# Altium schematic sheet LNA.SchDoc
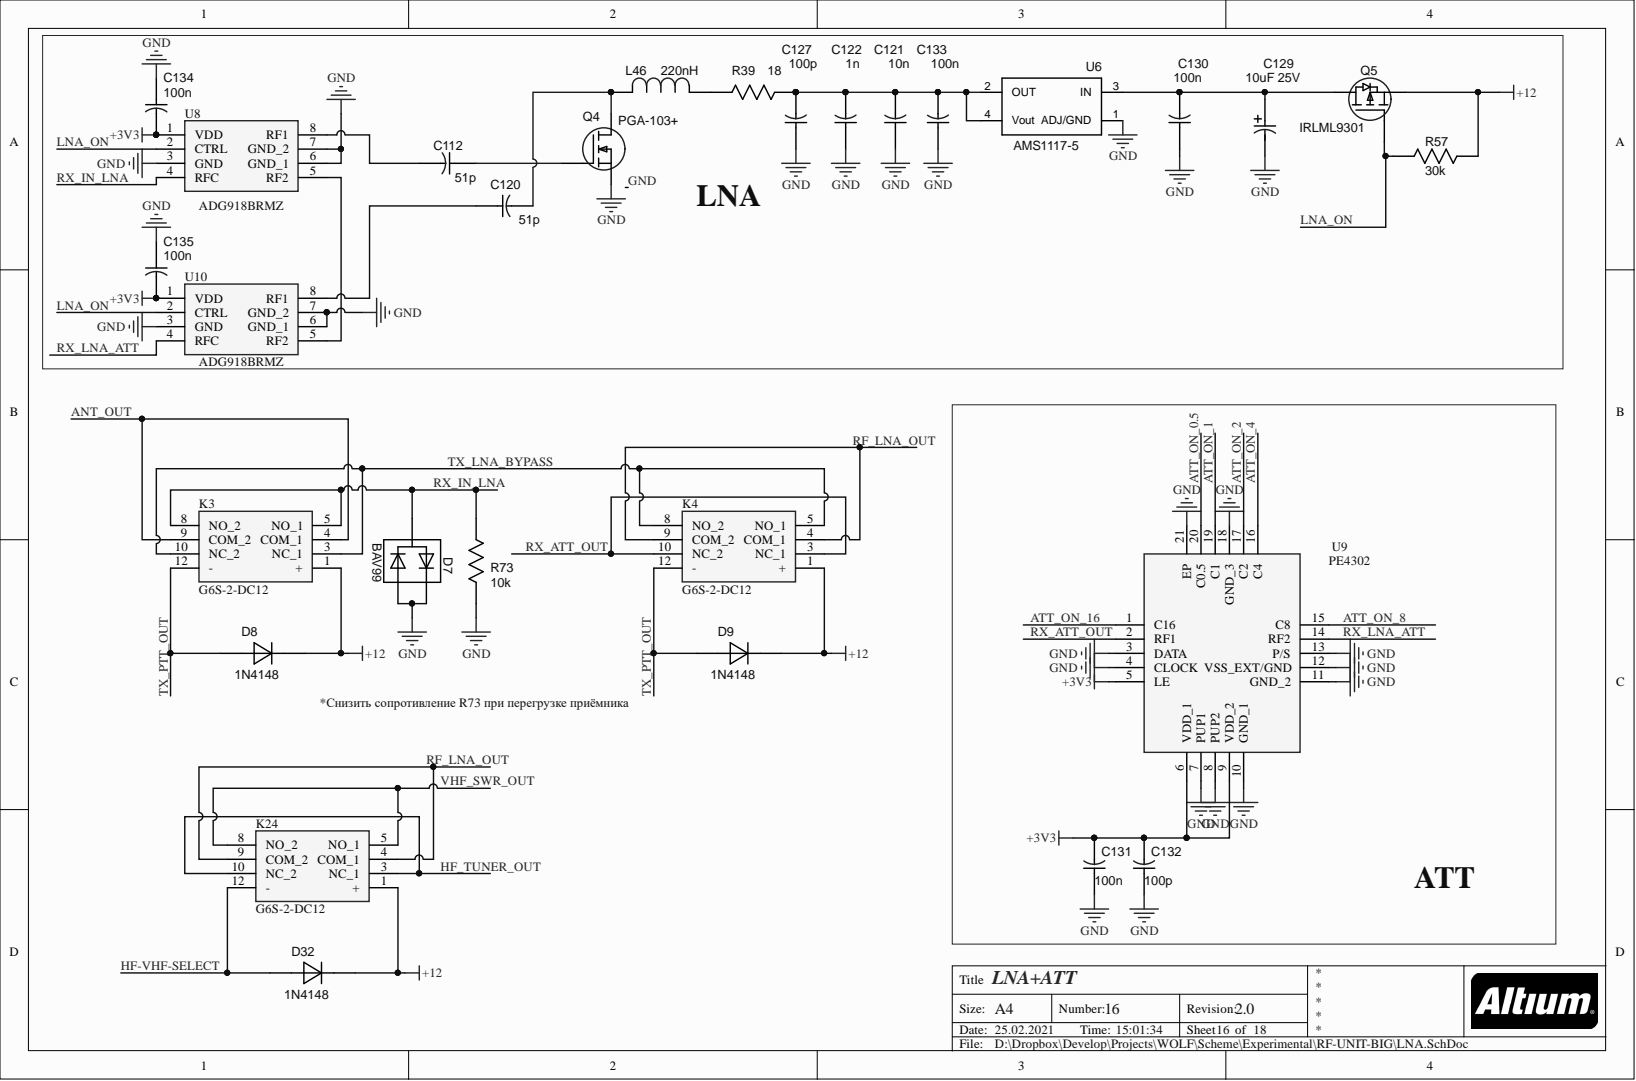
[diagram p0: LNA.SchDoc]

## Parts
C112  51p  [CAP_0805]
C120  51p  [CAP_0805]
C121  10n  [6-0805_M]
C122  1n  [6-0805_M]
C127  100p  [6-0805_M]
C129  10uF 25V  [CAPAE_5.3x5.3h6.1]
C130  100n  [CAP_0805]
C131  100n  [6-0805_M]
C132  100p  [6-0805_M]
C133  100n  [CAP_0805]
C134  100n  [CAP_0805]
C135  100n  [CAP_0805]
D7  BAV99  [SOT23]
D8  1N4148  [SS14]
D9  1N4148  [SS14]
D32  1N4148  [SS14]
K3  G6S-2-DC12  [G6S2DC12]
K4  G6S-2-DC12  [G6S2DC12]
K24  G6S-2-DC12  [G6S2DC12]
L46  220nH  [IND_1206]
Q4  PGA-103+  [SOT89]
Q5  IRLML9301  [SOT23]
R39  18  [RES_1206]
R57  30k  [RES_0805]
R73  10k  [RES_1206]
U6  AMS1117-5  [AMS1117-5]
U8  ADG918BRMZ  [SOP65P490X110-8N]
U9  PE4302  [QFN50P400X400X90-21N]
U10  ADG918BRMZ  [SOP65P490X110-8N]

## Nets
+3V3: C131.2 C132.2 C134.2 C135.2 U8.1(VDD) U9.5(LE) U9.6(VDD_1) U9.9(VDD_2) U10.1(VDD)
+12: D8.1 D9.1 D32.1 K3.1(+) K4.1(+) K24.1(+) Q5.2 R57.2
ANT_OUT: K3.4(COM_1) K3.9(COM_2)
ATT_ON_0.5: U9.20(C0.5)
ATT_ON_1: U9.19(C1)
ATT_ON_2: U9.17(C2)
ATT_ON_4: U9.16(C4)
ATT_ON_8: U9.15(C8)
ATT_ON_16: U9.1(C16)
GND: C121.1 C122.1 C127.1 C129.2 C130.1 C131.1 C132.1 C133.1 C134.1 C135.1 D7.1 D7.2 Q4.0 Q4.2 R73.1 U6.1(ADJ/GND) U8.3(GND) U8.6(GND_1) U8.7(GND_2) U9.3(DATA) U9.4(CLOCK) U9.7(PUP1) U9.8(PUP2) U9.10(GND_1) U9.11(GND_2) U9.12(VSS_EXT/GND) U9.13(P/S) U9.18(GND_3) U9.21(EP) U10.3(GND) U10.6(GND_1) U10.7(GND_2)
HF-VHF-SELECT: D32.2 K24.12(-)
HF_TUNER_OUT: K24.3(NC_1) K24.10(NC_2)
LNA_ON: Q5.1 R57.1 U8.2(CTRL) U10.2(CTRL)
RF_LNA_OUT: K4.4(COM_1) K4.9(COM_2) K24.4(COM_1) K24.9(COM_2)
RX_ATT_OUT: K4.3(NC_1) K4.10(NC_2) U9.2(RF1)
RX_IN_LNA: D7.3 K3.5(NO_1) K3.8(NO_2) R73.2 U8.4(RFC)
RX_LNA_ATT: U9.14(RF2) U10.4(RFC)
TX_LNA_BYPASS: K3.3(NC_1) K3.10(NC_2) K4.5(NO_1) K4.8(NO_2)
TX_PTT_OUT: D8.2 D9.2 K3.12(-) K4.12(-)
VHF_SWR_OUT: K24.5(NO_1) K24.8(NO_2)
NetC112_1: C112.1 Q4.1
NetC112_2: C112.2 U8.8(RF1)
NetC120_1: C120.1 U10.8(RF1)
NetC120_2: C120.2 L46.2 Q4.3
NetC121_2: C121.2 C122.2 C127.2 C133.2 R39.1 U6.2(OUT) U6.4(Vout)
NetC129_1: C129.1 C130.2 Q5.3 U6.3(IN)
NetL46_1: L46.1 R39.2
NetU8_5: U8.5(RF2) U10.5(RF2)

## Library data of the parts
C112 C120-C122 C127 C130-C135 = CAP_0805: Component Kind: Standard; Description: CAP_0805
  C121: Value: 10n
  C122: Value: 1n
  C127 C132: Value: 100p
  C130 C131 C133-C135: Value: 100n
C129 = CAPAE_5.3x5.3h6.1: Component Kind: Standard; Description: CAPAE_5.3x5.3h6.1; Value: 10uF 25V
K3 K4 K24 = G6S-2-DC12: Relay or Contactor; Manufacturer_Name: Omron Electronics; Component Kind: Standard; Datasheet Link: https://componentsearchengine.com/Datasheets/1/G6S-2-DC12.pdf; Height: 9.4mm; Manufacturer_Part_Number: G6S-2-DC12; Mouser Part Number: 653-G6S-2-DC12A; Mouser Price/Stock: https://www.mouser.co.uk/ProductDetail/Omron-Electronics/G6S-2-DC12/?qs=QGk6feVlqMLN9Ouv0QsFzA%3D%3D
L46 = IND_1206: Component Kind: Standard; Description: IND_1206; Value: 220nH
Q4 = PGA-103+: MOSFET; Component Kind: Standard; Value: PGA-103+
Q5 = MOSFET_ENH_PD: MOSFET; Component Kind: Standard; Value: IRLML9301
R39 R73 = RES_1206: Component Kind: Standard; Description: RES_1206
  R39: Value: 18
R57 = RES_0805: Component Kind: Standard; Description: RES_0805; Value: 30k
U8 U10 = ADG918BRMZ: Integrated Circuit; Manufacturer_Name: Analog Devices; Component Kind: Standard; Datasheet Link: http://www.analog.com/static/imported-files/data_sheets/ADG918_919.pdf; Height: 1.1mm; Manufacturer_Part_Number: ADG918BRMZ; Mouser Part Number: 584-ADG918BRMZ; Mouser Price/Stock: https://www.mouser.co.uk/ProductDetail/Analog-Devices/ADG918BRMZ?qs=BpaRKvA4VqHrp1TCwMhfnw%3D%3D; RS Part Number: 4969212P; RS Price/Stock: http://uk.rs-online.com/web/p/products/4969212P; Library Name: RF-UNIT-BIG.SchLib
U9 = PE4312C-Z: Integrated Circuit; Manufacturer_Name: pSemi; Component Kind: Standard; Datasheet Link: https://www.psemi.com/pdf/datasheets/pe4312ds.pdf; Height: 0.9mm; Manufacturer_Part_Number: PE4312C-Z; Mouser Part Number: N/A; Mouser Price/Stock: https://www.mouser.com/Search/Refine.aspx?Keyword=N%2FA; Library Name: RF-UNIT-BIG.SchLib
D7 = BAV99: Component Kind: Standard; Description: BAV99; Value: BAV99
D8 D9 D32 = 1N4148: Component Kind: Standard; Description: 1N4148; Library Name: RF-UNIT-BIG.SchLib
U6 = AMS1117-5: Component Kind: Standard; Description: AMS1117-5; Value: AMS1117-5
